# Altium schematic sheet power.SchDoc
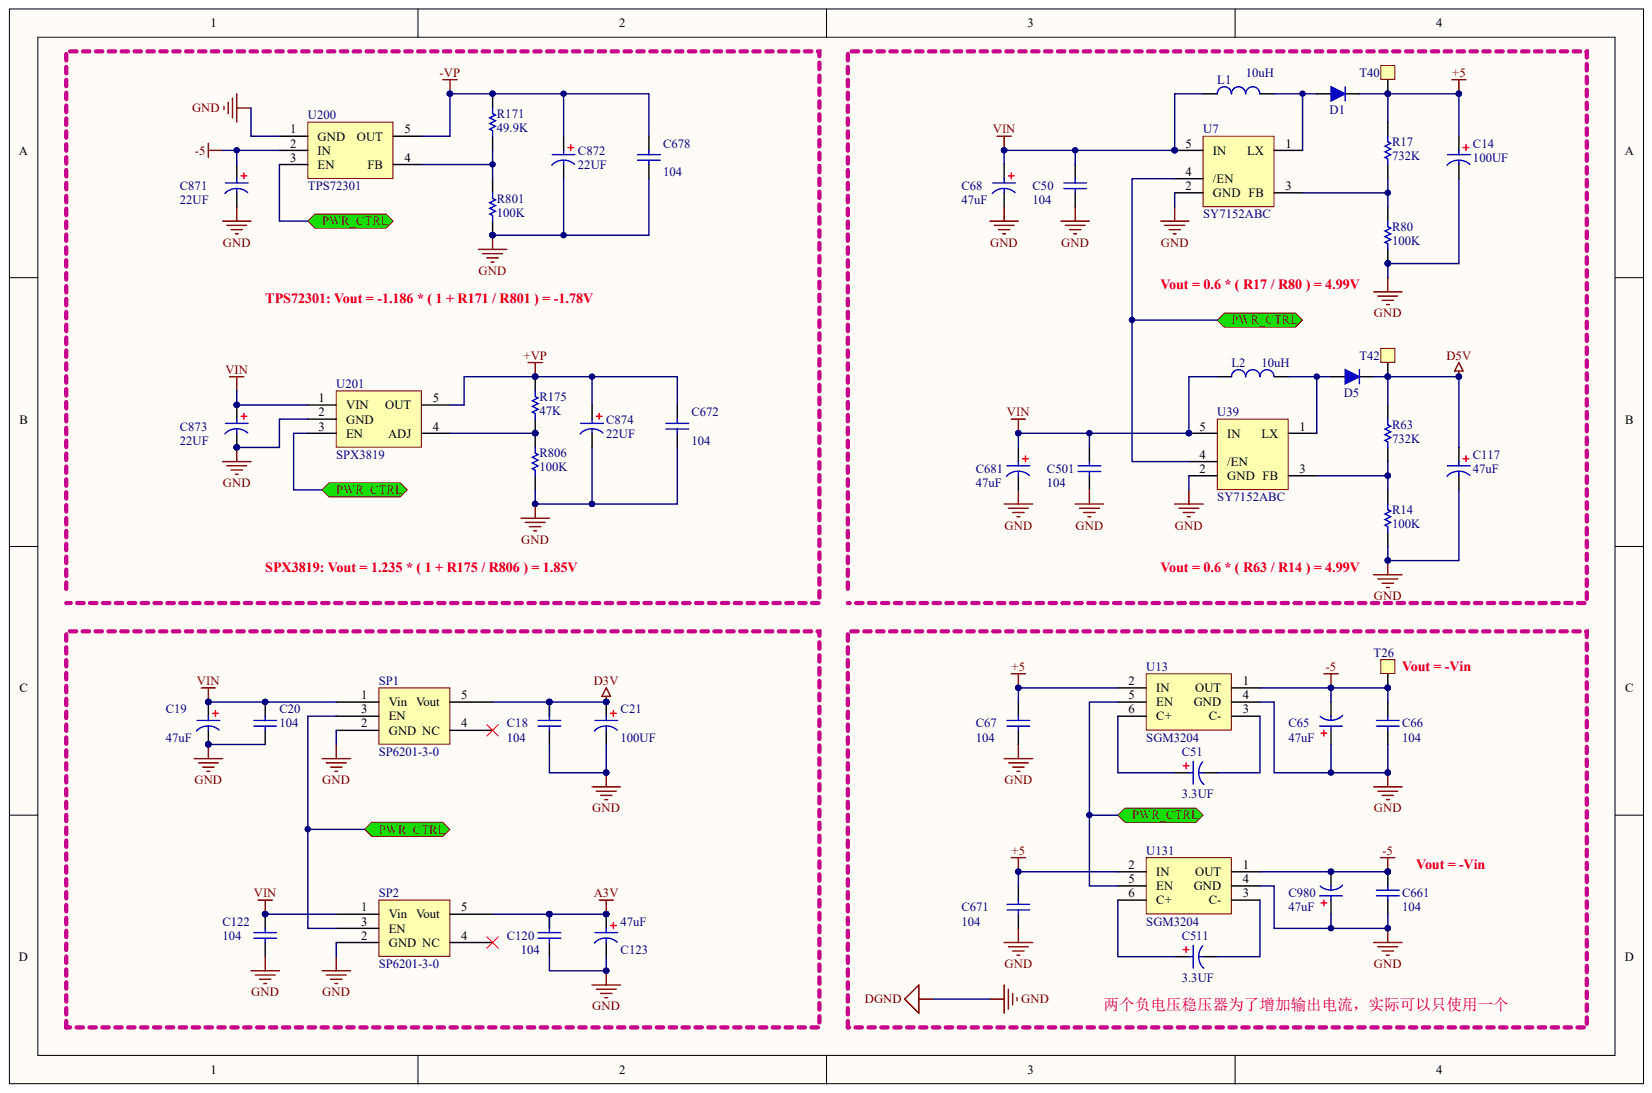
[diagram p0: power.SchDoc]

## Parts
C14  47uF  (value not drawn)
C18  C104  (value not drawn)
C19  47uF
C20  C104  (value not drawn)
C21  47uF  (value not drawn)
C50  C104  (value not drawn)
C51  3.3UF
C65  47uF
C66  C104  (value not drawn)
C67  C104  (value not drawn)
C68  47uF
C117  47uF
C120  C104  (value not drawn)
C122  C104  (value not drawn)
C123  47uF
C501  C104  (value not drawn)
C511  3.3UF
C661  C104  (value not drawn)
C671  C104  (value not drawn)
C672  C104  (value not drawn)
C678  C104  (value not drawn)
C681  47uF
C871  22UF
C872  22UF
C873  22UF
C874  22UF
C980  47uF
D1  1N5918WS  (value not drawn)
D5  1N5918WS  (value not drawn)
L1  10uH
L2  10uH
R14  100K
R17  732K
R63  732K
R80  100K
R171  49.9K
R175  47K
R801  100K
R806  100K
SP1  SP6201-3-0
SP2  SP6201-3-0
T26  TP  (value not drawn)
T40  TP  (value not drawn)
T42  TP  (value not drawn)
U7  SY7152ABC
U13  SGM3204
U39  SY7152ABC
U131  SGM3204
U200  TPS72301
U201  SPX3819

## Ports
PWR_CTRL

## Nets
+5: C14.1 C67.1 C671.1 D1.2 R17.2 T40.1 U13.2(IN) U131.2(IN)
+VP: C672.1 C874.1 R175.2 U201.5(OUT)
-5: C65.2 C66.1 C661.1 C871.1 C980.2 T26.1 U13.1(OUT) U131.1(OUT) U200.2(IN)
-VP: C678.1 C872.1 R171.2 U200.5(OUT)
A3V: C120.1 C123.1 SP2.5(Vout)
D3V: C18.1 C21.1 SP1.5(Vout)
D5V: C117.1 D5.2 R63.2 T42.1
DGND: C14.2 C18.2 C19.2 C20.2 C21.2 C50.2 C65.1 C66.2 C67.2 C68.2 C117.2 C120.2 C122.2 C123.2 C501.2 C661.2 C671.2 C672.2 C678.2 C681.2 C871.2 C872.2 C873.2 C874.2 C980.1 R14.2 R80.2 R801.2 R806.2 SP1.2(GND) SP2.2(GND) U7.2(GND) U13.4(GND) U39.2(GND) U131.4(GND) U200.1(GND) U201.2(GND)
VIN: C19.1 C20.1 C50.1 C68.1 C122.1 C501.1 C681.1 C873.1 L1.1 L2.1 SP1.1(Vin) SP2.1(Vin) U7.5(IN) U39.5(IN) U201.1(VIN)
PWR_CTRL: SP1.3(EN) SP2.3(EN) U7.4(/EN) U13.5(EN) U39.4(/EN) U131.5(EN) U200.3(EN) U201.3(EN) ; port PWR_CTRL
NetC51_1: C51.1 U13.6(C+)
NetC51_2: C51.2 U13.3(C-)
NetC511_1: C511.1 U131.6(C+)
NetC511_2: C511.2 U131.3(C-)
NetD1_1: D1.1 L1.2 U7.1(LX)
NetD5_1: D5.1 L2.2 U39.1(LX)
NetR14_1: R14.1 R63.1 U39.3(FB)
NetR17_1: R17.1 R80.1 U7.3(FB)
NetR171_1: R171.1 R801.1 U200.4(FB)
NetR175_1: R175.1 R806.1 U201.4(ADJ)

Not connected: SP1 4(NC); SP2 4(NC)

## Library data of the parts
C14 C18-C21 C50 C51 C65-C68 C117 C120 C122 C123 C501 C511 C661 C671 C672 C678 C681 C871-C874 C980 D1 D5 L1 L2 R14 R17 R63 R80 R171 R175 R801 R806 SP1 SP2 T26 T40 T42 U7 U13 U39 U131 U200 U201 = (no symbol name)
  C14 C21: : 100UF
  C18 C20 C50 C66 C67 C120 C122 C501 C661 C671 C672 C678: : 104
  C19 C65 C68 C117 C123 C681 C980: : 47uF
  C51 C511: : 3.3UF
  C871-C874: : 22UF
  D1 D5: : 1N5819WS
  L1 L2: : 10uH
  R14 R80 R801 R806: : 100K
  R17 R63: : 732K
  R171: : 49.9K
  R175: : 47K
